# Altium schematic sheet Microcontroller.SchDoc(Microcontroller)
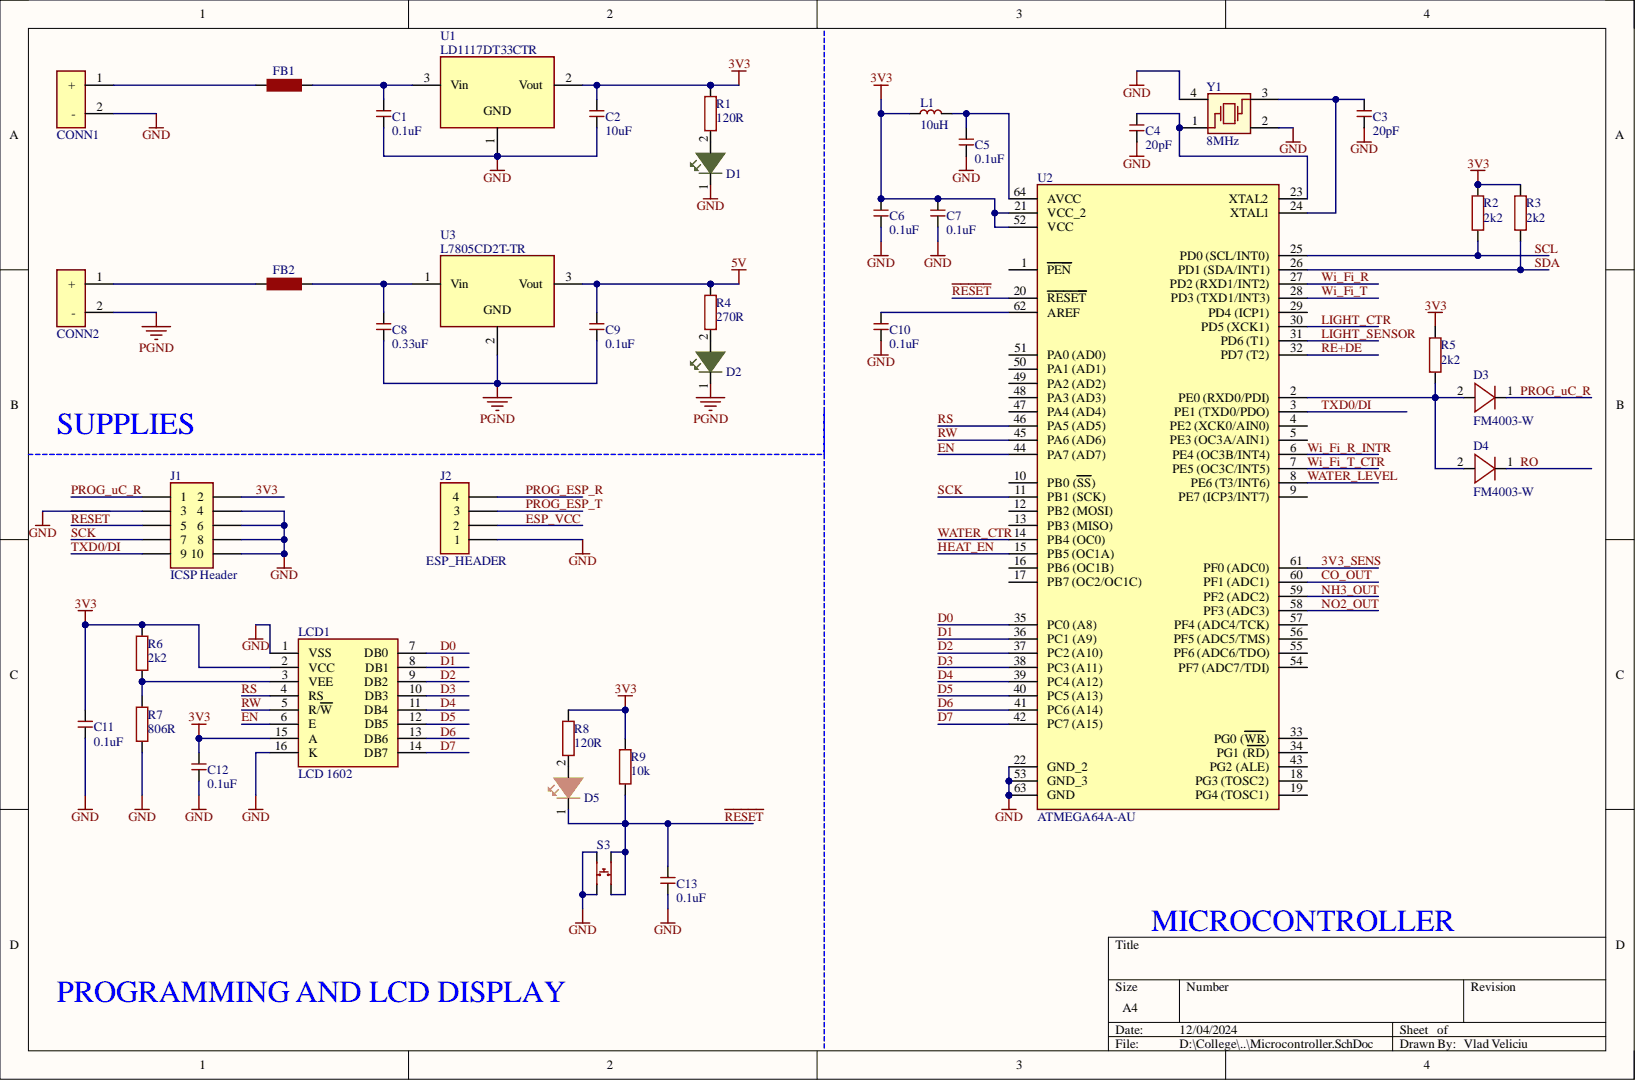
[diagram p0: Microcontroller.SchDoc]

## Parts
C1  0.1uF  [C0603]
C2  10uF  [C0805]
C3  20pF  [C0805]
C4  20pF  [C0805]
C5  0.1uF  [C0603]
C6  0.1uF  [C0603]
C7  0.1uF  [C0603]
C8  0.33uF  [C1206]
C9  0.1uF  [C0603]
C10  0.1uF  [C0603]
C11  0.1uF  [C0603]
C12  0.1uF  [C0603]
C13  0.1uF  [C0603]
CONN1  BAT_TERM  [1760510000]  (value not drawn)
CONN2  BAT_TERM  [1760510000]  (value not drawn)
D1  150060VS75000  [LED-0603]  (value not drawn)
D2  150060VS75000  [LED-0603]  (value not drawn)
D3  FM4003-W  [FM4003]
D4  FM4003-W  [FM4003]
D5  150060AS75000  [LED-0603]  (value not drawn)
FB1  BLM21SP181SZ1D  [FB-0805]  (value not drawn)
FB2  BLM21SP181SZ1D  [FB-0805]  (value not drawn)
J1  ICSP Header  [15916100]
J2  ESP_HEADER  [TMM-104-YY-XX-D-SM-4pins]
L1  10uH  [L-0805]
LCD1  LCD 1602  [LCD 1602]
R1  120R  [R0805]
R2  2k2  [R0805]
R3  2k2  [R0805]
R4  270R  [R1206]
R5  2k2  [R0805]
R6  2k2  [R0805]
R7  806R  [R0805]
R8  120R  [R0805]
R9  10k  [R0805]
S3  Pushbutton  [Pushbutton]  (value not drawn)
U1  LD1117DT33CTR  [DPAK]
U2  ATMEGA64A-AU  [64-TQFP]
U3  L7805CD2T-TR  [D2PAK]
Y1  8MHz  [ECS-80]

## Nets
5V: C9.2 R4.2 U3.3
GND: C1.1 C2.1 C3.1 C4.1 C5.1 C6.1 C7.1 C10.1 C11.1 C12.1 C13.1 CONN1.2 D1.1 J1.3 J1.4 J1.6 J1.8 J1.10 J2.1 LCD1.1(VSS) LCD1.16(K) R7.1 S3.3 S3.4 U1.1 U2.22(GND_2) U2.53(GND_3) U2.63(GND) Y1.2 Y1.4
PGND: C8.1 C9.1 CONN2.2 D2.1 U3.2
3V3: C2.2 C6.2 C7.2 C11.2 C12.2 J1.2 L1.1 LCD1.2(VCC) LCD1.15(A) R1.2 R2.2 R3.2 R5.2 R6.2 R8.2 R9.2 U1.2 U2.21(VCC_2) U2.52(VCC)
3V3_SENS: U2.61(PF0_(ADC0))
CO_OUT: U2.60(PF1_(ADC1))
D0: LCD1.7(DB0) U2.35(PC0_(A8))
D1: LCD1.8(DB1) U2.36(PC1_(A9))
D2: LCD1.9(DB2) U2.37(PC2_(A10))
D3: LCD1.10(DB3) U2.38(PC3_(A11))
D4: LCD1.11(DB4) U2.39(PC4_(A12))
D5: LCD1.12(DB5) U2.40(PC5_(A13))
D6: LCD1.13(DB6) U2.41(PC6_(A14))
D7: LCD1.14(DB7) U2.42(PC7_(A15))
EN: LCD1.6(E) U2.44(PA7_(AD7))
ESP_VCC: J2.2
HEAT_EN: U2.15(PB5_(OC1A))
LIGHT_CTR: U2.30(PD5_(XCK1))
LIGHT_SENSOR: U2.31(PD6_(T1))
NH3_OUT: U2.59(PF2_(ADC2))
NO2_OUT: U2.58(PF3_(ADC3))
PROG_ESP_R: J2.4
PROG_ESP_T: J2.3
PROG_uC_R: D3.1 J1.1
~{RESET}: C13.2 D5.1 J1.5 R9.1 S3.1 S3.2 U2.20(RESET)
RE+DE: U2.32(PD7_(T2))
RO: D4.1
RS: LCD1.4(RS) U2.46(PA5_(AD5))
RW: LCD1.5(R/W) U2.45(PA6_(AD6))
SCK: J1.7 U2.11(PB1_(SCK))
SCL: R2.1 U2.25(PD0_(SCL/INT0))
SDA: R3.1 U2.26(PD1_(SDA/INT1))
TXD0/DI: J1.9 U2.3(PE1_(TXD0/PDO))
WATER_CTR: U2.14(PB4_(OC0))
WATER_LEVEL: U2.8(PE6_(T3/INT6))
Wi_Fi_R: U2.27(PD2_(RXD1/INT2))
Wi_Fi_R_INTR: U2.6(PE4_(OC3B/INT4))
Wi_Fi_T: U2.28(PD3_(TXD1/INT3))
Wi_Fi_T_CTR: U2.7(PE5_(OC3C/INT5))
NetC1_2: C1.2 FB1.2 U1.3
NetC3_2: C3.2 U2.24(XTAL1) Y1.3
NetC4_2: C4.2 U2.23(XTAL2) Y1.1
NetC5_2: C5.2 L1.2 U2.64(AVCC)
NetC8_2: C8.2 FB2.2 U3.1
NetC10_2: C10.2 U2.62(AREF)
NetCONN1_1: CONN1.1 FB1.1
NetCONN2_1: CONN2.1 FB2.1
NetD1_2: D1.2 R1.1
NetD2_2: D2.2 R4.1
NetD3_2: D3.2 D4.2 R5.1 U2.2(PE0_(RXD0/PDI))
NetD5_2: D5.2 R8.1
NetLCD1_3: LCD1.3(VEE) R6.1 R7.2

Not connected: U2 1(PEN) 4(PE2_(XCK0/AIN0)) 5(PE3_(OC3A/AIN1)) 9(PE7_(ICP3/INT7)) 10(PB0_(SS)) 12(PB2_(MOSI)) 13(PB3_(MISO)) 16(PB6_(OC1B)) 17(PB7_(OC2/OC1C)) 18(PG3_(TOSC2)) 19(PG4_(TOSC1)) 29(PD4_(ICP1)) 33(PG0_(WR)) 34(PG1_(RD)) 43(PG2_(ALE)) 47(PA4_(AD4)) 48(PA3_(AD3)) 49(PA2_(AD2)) 50(PA1_(AD1)) 51(PA0_(AD0)) 54(PF7_(ADC7/TDI)) 55(PF6_(ADC6/TDO)) 56(PF5_(ADC5/TMS)) 57(PF4_(ADC4/TCK))

## Library data of the parts
C1 C5-C7 C9-C13 = C0603C104K8RAC: Ceramic Capacitor, Multilayer, Ceramic, 10V, 10% +Tol, 10% -Tol, X7R, 15% TC, 0.1uF, Surface Mount, 0603; Manufacturer: KEMET; Multilayer: Yes; Value: 100nF; Capacitor Type: Ceramic; Case/Package: 0603; Category: Ceramic Capacitors; Component Kind: Standard; ComponentLink1Description: https://datasheet.ciiva.com:443/pdfs/VipMasterIC/IC/KEME/KEME-S-A0008991654/KEME-S-A0008991654-1.pdf?src-supplier=IHS+Markit; ComponentLink1URL: https://datasheet.ciiva.com:443/pdfs/VipMasterIC/IC/KEME/KEME-S-A0008991654/KEME-S-A0008991654-1.pdf?src-supplier=IHS+Markit; ComponentLink2Description: http://www.mouser.com/ds/2/212/KEM_C1002_X7R_SMD-1102033.pdf?src-supplier=Mouser; ComponentLink2URL: http://www.mouser.com/ds/2/212/KEM_C1002_X7R_SMD-1102033.pdf?src-supplier=Mouser; Dielectric Material: Ceramic; Height: 0.8mm; JESD-609 Code: e3; Length: 1.6mm; Library Name: Schlib1.SchLib; Manufacturer Part Number: C0603C104K8RAC; Max Operating Temperature: 125°C; Min Operating Temperature: -55°C; Mounting Technology: Surface Mount; Package Shape: Rectangular; Package Style: SMTMeter; Packaging: Tape and Reel; Pins: 2; Pricing: 1=0.1, 10=0.061, 50=0.061, 100=0.031, 1000=0.022, 10000=0.015 (USD); Rated DC Voltage (URdc): 10V; Reach SVHC Compliant: Yes; RoHS: Yes; RoHS Compliant: Yes; Stock: 209299; Supplier: Mouser; Supplier Part Number: 80-C0603C104K8RAC; Temperature Characteristics Code: X7R; Terminal Finish: Nickel; Termination: Wraparound; Tolerance  (fill in as +-): 10%; Tolerance (fill in as +-): 10%; Width: 0.8mm
C2 = C0805C106K8PACTU: Ceramic Capacitor, Multilayer, Ceramic, 10V, 10% +Tol, 10% -Tol, X5R, 15% TC, 10uF, Surface Mount, 0805; Manufacturer: KEMET; Multilayer: Yes; Capacitor Type: Ceramic; Case/Package: 0805; Category: Ceramic Capacitors; Component Kind: Standard; ComponentLink1Description: https://datasheet.ciiva.com:443/pdfs/VipMasterIC/IC/KEME/KEME-S-A0008991665/KEME-S-A0008991665-1.pdf?src-supplier=IHS+Markit; ComponentLink1URL: https://datasheet.ciiva.com:443/pdfs/VipMasterIC/IC/KEME/KEME-S-A0008991665/KEME-S-A0008991665-1.pdf?src-supplier=IHS+Markit; ComponentLink2Description: https://datasheet.ciiva.com/7842/1732709-7842198.pdf?src-supplier=Element14; ComponentLink2URL: https://datasheet.ciiva.com/7842/1732709-7842198.pdf?src-supplier=Element14; ComponentLink3Description: https://datasheet.ciiva.com/22704/1732709-22704859.pdf?src-supplier=Element14; ComponentLink3URL: https://datasheet.ciiva.com/22704/1732709-22704859.pdf?src-supplier=Element14; ComponentLink4Description: https://datasheet.ciiva.com/7842/40889-7842552.pdf?src-supplier=Element14; ComponentLink4URL: https://datasheet.ciiva.com/7842/40889-7842552.pdf?src-supplier=Element14; Dielectric Material: Ceramic; Height: 1.25mm; JESD-609 Code: e3; Length: 2mm; Library Name: Schlib1.SchLib; Manufacturer Part Number: C0805C106K8PACTU; Max Operating Temperature: 85°C; Min Operating Temperature: -55°C; Mounting Technology: Surface Mount; Package Shape: Rectangular; Package Style: SMTMeter; Packaging: Tape and Reel; Pins: 2; Pricing: 1=0.14, 10=0.098, 50=0.0584, 100=0.0459, 500=0.03388, 1000=0.02805 (USD); Rated DC Voltage (URdc): 10V; Reach SVHC Compliant: Yes; RoHS: Yes; RoHS Compliant: Yes; Stock: 5642299; Supplier: Digikey; Supplier Part Number: 399-C0805C106K8PAC7800CT-ND; Temperature Characteristics Code: X5R; Terminal Finish: Nickel; Termination: Wraparound; Tolerance  (fill in as +-): 10%; Tolerance (fill in as +-): 10%; Value: 10uF; Width: 1.25mm
C3 C4 = C0805C200J3GACTU: Ceramic Capacitor, Multilayer, Ceramic, 25V, 5% +Tol, 5% -Tol, C0G, 30ppm/Cel TC, 0.00002uF, Surface Mount, 0805; Manufacturer: KEMET; Multilayer: Yes; Capacitor Type: Ceramic; Case/Package: 0805; Category: Ceramic Capacitors; Component Kind: Standard; ComponentLink1Description: https://datasheet.ciiva.com:443/pdfs/VipMasterIC/IC/KEME/KEME-S-A0008839401/KEME-S-A0008839438-1.pdf?src-supplier=IHS+Markit; ComponentLink1URL: https://datasheet.ciiva.com:443/pdfs/VipMasterIC/IC/KEME/KEME-S-A0008839401/KEME-S-A0008839438-1.pdf?src-supplier=IHS+Markit; ComponentLink2Description: http://components.arrow.com/part/dataview/30753995S8614462N7429?src-supplier=Arrow+Electronics; ComponentLink2URL: http://components.arrow.com/part/dataview/30753995S8614462N7429?src-supplier=Arrow+Electronics; ComponentLink3Description: http://www.mouser.com/ds/2/212/KEM_C1003_C0G_SMD-1101588.pdf?src-supplier=Mouser; ComponentLink3URL: http://www.mouser.com/ds/2/212/KEM_C1003_C0G_SMD-1101588.pdf?src-supplier=Mouser; ComponentLink4Description: https://api.kemet.com/component-edge/download/datasheet/C0805C200J3GACTU.pdf?src-supplier=Digikey; ComponentLink4URL: https://api.kemet.com/component-edge/download/datasheet/C0805C200J3GACTU.pdf?src-supplier=Digikey; Dielectric Material: Ceramic; Height: 0.78mm; JESD-609 Code: e3; Length: 2mm; Library Name: Schlib1.SchLib; Manufacturer Part Number: C0805C200J3GACTU; Max Operating Temperature: 125°C; Min Operating Temperature: -55°C; Mounting Technology: Surface Mount; Package Shape: Rectangular; Package Style: SMTMeter; Packaging: Tape and Reel; Pins: 2; Pricing: 1=0.2, 10=0.122, 50=0.122, 100=0.073, 1000=0.064, 10000=0.05 (USD); Rated DC Voltage (URdc): 25V; Reach SVHC Compliant: Yes; RoHS: Yes; RoHS Compliant: Yes; Stock: 10386; Supplier: Mouser; Supplier Part Number: 80-C0805C200J3G; Temperature Characteristics Code: C0G; Terminal Finish: Nickel; Termination: Wraparound; Tolerance  (fill in as +-): 5%; Tolerance (fill in as +-): 5%; Value: 20pF; Width: 1.25mm
C8 = C1206C334K1RECAUTO: Ceramic Capacitor, Multilayer, Ceramic, 100V, 10% +Tol, 10% -Tol, X7R, 15% TC, 0.33uF, Surface Mount, 1206; Manufacturer: KEMET; Capacitor Type: Ceramic; Category: Ceramic Capacitors; Component Kind: Standard; ComponentLink1Description: https://datasheet.ciiva.com:443/pdfs/VipMasterIC/IC/KEME/KEME-S-A0008958450/KEME-S-A0008958450-1.pdf?src-supplier=IHS+Markit; ComponentLink1URL: https://datasheet.ciiva.com:443/pdfs/VipMasterIC/IC/KEME/KEME-S-A0008958450/KEME-S-A0008958450-1.pdf?src-supplier=IHS+Markit; ComponentLink2Description: https://api.kemet.com/component-edge/download/datasheet/C1206C334K1RECAUTO.pdf?src-supplier=Digikey; ComponentLink2URL: https://api.kemet.com/component-edge/download/datasheet/C1206C334K1RECAUTO.pdf?src-supplier=Digikey; Dielectric Material: Ceramic; Library Name: Schlib1.SchLib; Manufacturer Part Number: C1206C334K1RECAUTO; Pins: 2; Pricing: 1=0.31, 10=0.15, 50=0.15, 100=0.096, 1000=0.081, 10000=0.066 (USD); Reach SVHC Compliant: Yes; RoHS: Yes; RoHS Compliant: Yes; Stock: 50773; Supplier: Mouser; Supplier Part Number: 80-C1206C334K1RECAUT
CONN1 CONN2 = BAT_TERM: Strip Terminal Block, 24A, 2.5mm2, 1 Row(s), 1 Deck(s); Manufacturer: Weidmuller; Additional Feature: STANDARD: UL 94V-0; Fastening Method: SOLDER; Number of Decks: 1; Number of Ways: 2; Safety Approval: UL,CSA; Voltage Rating: 600V; Wire/Cable Gauge: 14AWG; Category: Terminal Blocks; Component Kind: Standard; ComponentLink1Description: https://datasheet.ciiva.com:443/pdfs/VipMasterIC/IC/WEID/WEIDS07857/WEIDS07857-1.pdf?src-supplier=IHS+Markit; ComponentLink1URL: https://datasheet.ciiva.com:443/pdfs/VipMasterIC/IC/WEID/WEIDS07857/WEIDS07857-1.pdf?src-supplier=IHS+Markit; ComponentLink2Description: https://datasheet.ciiva.com/823/1760510000-823577.pdf?src-supplier=Digikey; ComponentLink2URL: https://datasheet.ciiva.com/823/1760510000-823577.pdf?src-supplier=Digikey; ComponentLink3Description: https://datasheet.ciiva.com/7143/1712606-7143944.pdf?src-supplier=Newark; ComponentLink3URL: https://datasheet.ciiva.com/7143/1712606-7143944.pdf?src-supplier=Newark; ComponentLink4Description: https://datasheet.ciiva.com/16071/1760510000-pm-5-08-02-90-3-5sn-bk-bx-en-pdf-154-16071541.pdf?src-supplier=Onlinecomponents.com; ComponentLink4URL: https://datasheet.ciiva.com/16071/1760510000-pm-5-08-02-90-3-5sn-bk-bx-en-pdf-154-16071541.pdf?src-supplier=Onlinecomponents.com; Current Rating: 24A; Library Name: Schlib1.SchLib; Manufacturer Part Number: 1760510000; Mounting Technology: Board; Number of Rows: 1; Pricing: 1=0.89, 10=0.554, 25=0.474, 50=0.4262, 100=0.4173, 250=0.35512, 500=0.33736, 1000=0.30185, 5000=0.23615 (USD); Reach SVHC Compliant: Yes; RoHS: Yes; RoHS Compliant: Yes; Stock: 49588; Supplier: Digikey; Supplier Part Number: 281-1882-ND; Terminal and Terminal Block Type: STRIP TERMINAL BLOCK; Wire Cross Section: 2.5mm2
D1 D2 = 150060VS75000: Single Color LED, Bright Green, Water Clear, 1.1mm; Manufacturer: Wurth Electronics; Channels: 1; Forward Current: 30mA; Luminous Intensity-Nom: 40mcd; Overall Height: 0.7mm; Shape: Rectangular; Viewing Angle: 140°; Category: LEDs; Color: Green; Component Kind: Standard; ComponentLink1Description: https://datasheet.ciiva.com:443/pdfs/VipMasterIC/IC/MIDC/MIDC-S-A0007768636/MIDC-S-A0007768636-1.pdf?src-supplier=IHS+Markit; ComponentLink1URL: https://datasheet.ciiva.com:443/pdfs/VipMasterIC/IC/MIDC/MIDC-S-A0007768636/MIDC-S-A0007768636-1.pdf?src-supplier=IHS+Markit; ComponentLink2Description: https://datasheet.ciiva.com/29562/150060vs75000-29562919.pdf?src-supplier=Digikey; ComponentLink2URL: https://datasheet.ciiva.com/29562/150060vs75000-29562919.pdf?src-supplier=Digikey; Lens Style: WATER CLEAR; Library Name: Schlib1.SchLib; Manufacturer Part Number: 150060VS75000; Max Operating Temperature: 85°C; Min Operating Temperature: -40°C; Mounting Technology: Surface Mount; Optoelectronic Device Type: SINGLE COLOR LED; Packaging: Tape and Reel; Pins: 2; Pricing: 1=0.15, 50=0.142, 100=0.122, 500=0.105, 1000=0.10125 (USD); Reach SVHC Compliant: Yes; RoHS: Yes; RoHS Compliant: Yes; Size: 1.1mm; Stock: 90757; Supplier: Digikey; Supplier Part Number: 732-4980-1-ND; Terminal Pitch: 1.6mm
D3 D4 = FM4003-W: Rectifier Diode, 1 Phase, 1 Element, 1A, 200V V(RRM), Silicon, DO-214AC; Manufacturer: Rectron; Forward Voltage: 1.1V; Non-rep Pk Forward Current-Max: 30A; Number of Elements: 1; Package Body Material: Plastic; Qualification Status: Not Qualified; Time @ Peak Reflow Temperature-Max (s): 10s; Category: Rectifier Diodes; Component Kind: Standard; ComponentLink1Description: https://datasheet.ciiva.com:443/pdfs/VipMasterIC/IC/RECT/RECT-S-A0006483850/RECT-S-A0006483850-1.pdf?src-supplier=IHS+Markit; ComponentLink1URL: https://datasheet.ciiva.com:443/pdfs/VipMasterIC/IC/RECT/RECT-S-A0006483850/RECT-S-A0006483850-1.pdf?src-supplier=IHS+Markit; ComponentLink2Description: http://www.mouser.com/ds/2/345/rectron_fm4001w-fm4007w-347498.pdf?src-supplier=Mouser; ComponentLink2URL: http://www.mouser.com/ds/2/345/rectron_fm4001w-fm4007w-347498.pdf?src-supplier=Mouser; Diode Element Material: SILICON; Diode Type: RECTIFIER; JEDEC-95 Code: DO-214AC; JESD-30 Code: R-PDSO-C2; JESD-609 Code: e3; Library Name: Schlib1.SchLib; Manufacturer Part Number: FM4003-W; Max Operating Temperature: 150°C; Max Output current: 1A; Package Shape: Rectangular; Package Style: SMALL OUTLINEMeter; Peak Reflow Temperature (Cel): 260°C; Pins: 2; Pricing: 1=0.24, 10=0.16, 50=0.16, 100=0.089, 1000=0.052, 10000=0.034 (USD); Reach SVHC Compliant: No; Rep Pk Reverse Voltage-Max: 200V; RoHS: Yes; RoHS Compliant: Yes; Stock: 13549; Supplier: Mouser; Supplier Part Number: 583-FM4003-W; Surface Mount: Yes; Terminal Finish: Tin; Terminal Form: C BEND; Terminal Position: DUAL
D5 = 150060AS75000: Single Color LED, Amber, Water Clear, 1.1mm; Manufacturer: Wurth Electronics; Category: LEDs; Component Kind: Standard; ComponentLink1Description: https://datasheet.ciiva.com:443/pdfs/VipMasterIC/IC/MIDC/MIDC-S-A0007768872/MIDC-S-A0007768872-1.pdf?src-supplier=IHS+Markit; ComponentLink1URL: https://datasheet.ciiva.com:443/pdfs/VipMasterIC/IC/MIDC/MIDC-S-A0007768872/MIDC-S-A0007768872-1.pdf?src-supplier=IHS+Markit; ComponentLink2Description: http://www.farnell.com/datasheets/2621639.pdf?src-supplier=Farnell; ComponentLink2URL: http://www.farnell.com/datasheets/2621639.pdf?src-supplier=Farnell; ComponentLink3Description: http://www.farnell.com/datasheets/2621639.pdf?src-supplier=Element14; ComponentLink3URL: http://www.farnell.com/datasheets/2621639.pdf?src-supplier=Element14; ComponentLink4Description: http://www.farnell.com/datasheets/2621639.pdf?src-supplier=Newark; ComponentLink4URL: http://www.farnell.com/datasheets/2621639.pdf?src-supplier=Newark; Library Name: Schlib1.SchLib; Manufacturer Part Number: 150060AS75000; Optoelectronic Device Type: SINGLE COLOR LED; Pricing: 1=0.15, 50=0.142, 100=0.122, 500=0.105 (USD); Reach SVHC Compliant: Yes; RoHS: Yes; RoHS Compliant: Yes; Stock: 19678; Supplier: Digikey; Supplier Part Number: 732-150060AS75000CT-ND
FB1 FB2 = BLM21SP181SZ1D: Ferrite Chip, 1 Function(s), 2.6A, 2 Pin(s); Manufacturer: Murata; Category: Ferrite Beads and Chips; Component Kind: Standard; ComponentLink1Description: https://datasheet.ciiva.com:443/pdfs/VipMasterIC/IC/MURE/MURE-S-A0008531011/MURE-S-A0008530072-1.pdf?src-supplier=IHS+Markit; ComponentLink1URL: https://datasheet.ciiva.com:443/pdfs/VipMasterIC/IC/MURE/MURE-S-A0008531011/MURE-S-A0008530072-1.pdf?src-supplier=IHS+Markit; ComponentLink2Description: http://www.farnell.com/datasheets/2622769.pdf?src-supplier=Farnell; ComponentLink2URL: http://www.farnell.com/datasheets/2622769.pdf?src-supplier=Farnell; ComponentLink3Description: https://www.murata.com/en-us/api/pdfdownloadapi?cate=luNoiseSupprFilteChipFerriBead&amp;partno=BLM21SP181SZ1%23&src-supplier=Digikey; ComponentLink3URL: https://www.murata.com/en-us/api/pdfdownloadapi?cate=luNoiseSupprFilteChipFerriBead&amp;partno=BLM21SP181SZ1%23&src-supplier=Digikey; ComponentLink4Description: http://www.farnell.com/datasheets/2622769.pdf?src-supplier=Element14; ComponentLink4URL: http://www.farnell.com/datasheets/2622769.pdf?src-supplier=Element14; ComponentLink5Description: http://www.farnell.com/datasheets/2622769.pdf?src-supplier=Newark; ComponentLink5URL: http://www.farnell.com/datasheets/2622769.pdf?src-supplier=Newark; Filter Type: FERRITE CHIP; Library Name: Schlib1.SchLib; Manufacturer Part Number: BLM21SP181SZ1D; Pricing: 1=0.26, 10=0.213, 25=0.1968, 50=0.1804, 100=0.1591, 250=0.1542, 500=0.13778, 1000=0.11154 (USD); Reach SVHC Compliant: Yes; RoHS: Yes; RoHS Compliant: Yes; Stock: 5057; Supplier: Digikey; Supplier Part Number: 490-18599-1-ND
J1 = 15-91-6100: Headers & Wire Housings CGrid SMT Bkwy Hdr T wy Hdr Tin 10Ckt T&R; Manufacturer: Molex; Category: Headers and Wire Housings; Component Kind: Standard; ComponentLink1Description: https://datasheet.ciiva.com/13571/0015916100-pcb-headers-158017-13571672.pdf?src-supplier=Mouser; ComponentLink1URL: https://datasheet.ciiva.com/13571/0015916100-pcb-headers-158017-13571672.pdf?src-supplier=Mouser; ComponentLink2Description: https://datasheet.ciiva.com/16727/0015916100-pcb-headers-16727185.pdf?; ComponentLink2URL: https://datasheet.ciiva.com/16727/0015916100-pcb-headers-16727185.pdf?; Library Name: Schlib1.SchLib; Manufacturer Part Number: 15-91-6100; Pricing: 1=3.33, 10=2.934, 100=2.3336 (USD); RoHS: Yes; Stock: 464; Supplier: Digikey; Supplier Part Number: 900-0015916100CT-ND
J2 = TMM-104-06-F-D-SM_4pins: Board Connector, 8 Contact(s), 2 Row(s), Male, Straight, 0.079 inch Pitch, Surface Mount Terminal, Black Insulator; Manufacturer: Samtec; Manufacturer Series: TMM-1; Body Breadth: 0.155inch; Body Depth: 0.109inch; Body Length: 0.316inch; Contact Pattern: RECTANGULAR; Contact Style: SQ PIN-SKT; DIN Conformance: No; Mounting Option 1: LOCKING; Number Of Connectors: 1; Number of Contacts: 8; Number Of PCB Rows: 2; Option: General Purpose; Plating Thickness: 3uin; Reference Standard: UL; Reliability: COMMERCIAL; Category: Headers and Wire Housings; Component Kind: Standard; ComponentLink1Description: https://datasheet.ciiva.com:443/pdfs/VipMasterIC/IC/SAMI/SAMI-S-A0001541321/SAMI-S-A0001541321-1.pdf?src-supplier=IHS+Markit; ComponentLink1URL: https://datasheet.ciiva.com:443/pdfs/VipMasterIC/IC/SAMI/SAMI-S-A0001541321/SAMI-S-A0001541321-1.pdf?src-supplier=IHS+Markit; ComponentLink2Description: https://datasheet.ciiva.com/10163/tmm-sm-10163085.pdf?src-supplier=Avnet; ComponentLink2URL: https://datasheet.ciiva.com/10163/tmm-sm-10163085.pdf?src-supplier=Avnet; Connector Type: BOARD CONNECTOR; Contact Finish - Mating: GOLD FLASH (3) OVER NICKEL (50); Contact Finish - Termination: MATTE TIN; Contact Gender: Male; Contact Material: Bronze; Filter Feature: No; Flammability Rating: UL94 V-0; IEC Conformance: No; Insulation Material: Polymer; JESD-609 Code: e3; Library Name: Schlib1.SchLib; Manufacturer Part Number: TMM-104-06-F-D-SM; Mating Contact Pitch: 0.079inch; Mating Contact Row Spacing: 0.079inch; MIL Conformance: No; Mixed Contacts: No; Mounting Style: STRAIGHT; Mounting Technology: Board; Number of Rows Loaded: 2; PCB Contact Pattern: RECTANGULAR; Pricing: 1=1.64, 10=1.424, 100=1.2726, 500=1.0605, 1000=0.909, 5000=0.80598, 10000=0.7878 (USD); Reach SVHC Compliant: Yes; RoHS: Yes; RoHS Compliant: Yes; Stock: 201; Supplier: Digikey; Supplier Part Number: TMM-104-06-F-D-SM-ND; Terminal Pitch: 2mm; Termination: SMD/SMT
L1 = AIML-0805-100K-T: General Purpose Inductor, 10uH, 10%, 1 Element, Ferrite-Core, SMD, 0805; Manufacturer: Abracon; Case/Size Code: 0805; Channels: 1; DC Resistance (DCR): 1.15R; Inductor Application: FILTER CHOKE; Max Current Rating: 15mA; Package Height: 0.85mm; Package Length: 2mm; Package Width: 1.25mm; Quality Factor-Min (at L-nom): 55; Self Resonant Frequency: 24MHz; Shape/Size Description: RECTANGULAR PACKAGE; Shielding: Shielded; Test Frequency: 2MHz; Category: Fixed Inductors; Component Kind: Standard; ComponentLink1Description: https://datasheet.ciiva.com:443/pdfs/VipMasterIC/IC/ABRC/ABRC-S-A0008586284/ABRC-S-A0008586284-1.pdf?src-supplier=IHS+Markit; ComponentLink1URL: https://datasheet.ciiva.com:443/pdfs/VipMasterIC/IC/ABRC/ABRC-S-A0008586284/ABRC-S-A0008586284-1.pdf?src-supplier=IHS+Markit; ComponentLink2Description: https://datasheet.ciiva.com/1053/aiml0805-1206-1053697.pdf?src-supplier=Digikey; ComponentLink2URL: https://datasheet.ciiva.com/1053/aiml0805-1206-1053697.pdf?src-supplier=Digikey; ComponentLink3Description: https://datasheet.ciiva.com/1053/aiml0805-1206-1053698.pdf?src-supplier=Digikey; ComponentLink3URL: https://datasheet.ciiva.com/1053/aiml0805-1206-1053698.pdf?src-supplier=Digikey; ComponentLink4Description: https://datasheet.ciiva.com/1053/aiml0805-1206-1053699.pdf?src-supplier=Digikey; ComponentLink4URL: https://datasheet.ciiva.com/1053/aiml0805-1206-1053699.pdf?src-supplier=Digikey; Construction: Multilayer Chip; Core Material: Ferrite; Inductance-Nom (L): 10uH; Inductor Type: GENERAL PURPOSE INDUCTOR; JESD-609 Code: e3; Library Name: Schlib1.SchLib; Manufacturer Part Number: AIML-0805-100K-T; Manufacturer Series: AIML-0805; Max Operating Temperature: 125°C; Min Operating Temperature: -55°C; Package Style: SMTMeter; Packaging: Tape and Reel; Pins: 2; Pricing: 1=0.14, 10=0.112, 25=0.1032, 50=0.0908, 100=0.0795, 250=0.07576, 500=0.0712, 1000=0.05454 (USD); Reach SVHC Compliant: Yes; RoHS: Yes; RoHS Compliant: Yes; Series: AIML-0805; Stock: 4904; Supplier: Digikey; Supplier Part Number: 535-11621-1-ND; Surface Mount: Yes; Terminal Finish: Nickel; Terminal Placement: Dual Ended; Termination: Wraparound; Tolerance: 10%
LCD1 = LCD 1602: Display; Component Kind: Standard; Datasheet Link: https://www.waveshare.com/datasheet/LCD_en_PDF/LCD1602.pdf; Library Name: Schlib1.SchLib
R1 R8 = RT0805DRD07120RL: Fixed Resistor, Thin Film, 0.125W, 120ohm, 150V, 0.5% +/-Tol, 25ppm/Cel, Surface Mount, 0805; Manufacturer: Yageo; Additional Feature: HIGH PRECISION; Package Height: 0.5mm; Package Length: 2mm; Package Width: 1.25mm; Power: 125mW; Rated Temperature: 70°C; Temperature Coefficient: 25ppm/°C; Case/Package: 0805; Category: Chip SMD Resistors; Component Kind: Standard; ComponentLink1Description: https://datasheet.ciiva.com:443/pdfs/VipMasterIC/IC/YAGO/YAGO-S-A0008510989/YAGO-S-A0008510989-1.pdf?src-supplier=IHS+Markit; ComponentLink1URL: https://datasheet.ciiva.com:443/pdfs/VipMasterIC/IC/YAGO/YAGO-S-A0008510989/YAGO-S-A0008510989-1.pdf?src-supplier=IHS+Markit; ComponentLink2Description: http://www.yageo.com/documents/recent/PYu-RT_1-to-0.01_RoHS_L_9.pdf?src-supplier=Digikey; ComponentLink2URL: http://www.yageo.com/documents/recent/PYu-RT_1-to-0.01_RoHS_L_9.pdf?src-supplier=Digikey; Construction: Chip; Library Name: Schlib1.SchLib; Manufacturer Part Number: RT0805DRD07120RL; Max Operating Temperature: 155°C; Min Operating Temperature: -55°C; Mounting Technology: Surface Mount; Package Style: SMTMeter; Packaging: Tape and Reel; Pins: 2; Pricing: 1=0.1, 10=0.076, 50=0.0606, 100=0.0545, 500=0.0418, 1000=0.03701 (USD); Reach SVHC Compliant: Yes; Resistor Type: FIXED RESISTOR; RoHS: Yes; RoHS Compliant: Yes; Stock: 4920; Supplier: Digikey; Supplier Part Number: 13-RT0805DRD07120RLCT-ND; Technology: THIN FILM; Termination: Wraparound; Tolerance: 0.5%; Value: 120R; Working Voltage: 150V
R2 R3 R5 R6 = RC0805DR-072K2L: Fixed Resistor, Metal Glaze/thick Film, 0.125W, 2200ohm, 150V, 0.5% +/-Tol, 100ppm/Cel, Surface Mount, 0805; Manufacturer: Yageo; Additional Feature: LASER TRIMMABLE; Package Height: 0.5mm; Package Length: 2mm; Package Width: 1.25mm; Power: 125mW; Rated Temperature: 70°C; Temperature Coefficient: 100ppm/°C; Value: 2.2kR; Case/Package: 0805; Category: Chip SMD Resistors; Component Kind: Standard; ComponentLink1Description: https://datasheet.ciiva.com:443/pdfs/VipMasterIC/IC/YAGO/YAGO-S-A0008145938/YAGO-S-A0008145938-1.pdf?src-supplier=IHS+Markit; ComponentLink1URL: https://datasheet.ciiva.com:443/pdfs/VipMasterIC/IC/YAGO/YAGO-S-A0008145938/YAGO-S-A0008145938-1.pdf?src-supplier=IHS+Markit; ComponentLink2Description: http://www.yageo.com.tw/exep/pages/download/literatures/PYu-R_INT-thick_7.pdf?src-supplier=Digikey; ComponentLink2URL: http://www.yageo.com.tw/exep/pages/download/literatures/PYu-R_INT-thick_7.pdf?src-supplier=Digikey; Construction: Chip; JESD-609 Code: e3; Library Name: Schlib1.SchLib; Manufacturer Part Number: RC0805DR-072K2L; Max Operating Temperature: 155°C; Min Operating Temperature: -55°C; Mounting Technology: Surface Mount; Package Shape: Rectangular; Package Style: SMTMeter; Packaging: Tape and Reel; Pins: 2; Pricing: 1=0.13, 10=0.063, 50=0.0414, 100=0.0352, 500=0.02476, 1000=0.02156 (USD); Reach SVHC Compliant: Yes; Resistor Type: FIXED RESISTOR; RoHS: Yes; RoHS Compliant: Yes; Stock: 19275; Supplier: Digikey; Supplier Part Number: 13-RC0805DR-072K2LCT-ND; Technology: METAL GLAZE/THICK FILM; Terminal Finish: Nickel; Termination: Wraparound; Tolerance: 0.5%; Working Voltage: 150V
R4 = RC1206FR-07270RL: Fixed Resistor, Metal Glaze/thick Film, 0.25W, 270ohm, 200V, 1% +/-Tol, 100ppm/Cel, Surface Mount, 1206; Manufacturer: Yageo; Additional Feature: LASER TRIMMABLE; Package Height: 0.55mm; Package Length: 3.1mm; Package Width: 1.6mm; Power: 250mW; Rated Temperature: 70°C; Temperature Coefficient: 100ppm/°C; Case/Package: 1206; Category: Chip SMD Resistors; Component Kind: Standard; ComponentLink1Description: https://datasheet.ciiva.com:443/pdfs/VipMasterIC/IC/YAGO/YAGO-S-A0008145938/YAGO-S-A0008145938-1.pdf?src-supplier=IHS+Markit; ComponentLink1URL: https://datasheet.ciiva.com:443/pdfs/VipMasterIC/IC/YAGO/YAGO-S-A0008145938/YAGO-S-A0008145938-1.pdf?src-supplier=IHS+Markit; ComponentLink2Description: https://datasheet.ciiva.com/11819/0900766b80c6630a-11819935.pdf?src-supplier=Rs; ComponentLink2URL: https://datasheet.ciiva.com/11819/0900766b80c6630a-11819935.pdf?src-supplier=Rs; ComponentLink3Description: https://datasheet.ciiva.com/6547/pyu-rc1206-51-rohs-l-4-6547272.pdf?src-supplier=Digikey; ComponentLink3URL: https://datasheet.ciiva.com/6547/pyu-rc1206-51-rohs-l-4-6547272.pdf?src-supplier=Digikey; ComponentLink4Description: https://datasheet.ciiva.com/6547/pyu-rc1206-51-rohs-l-4-6547273.pdf?src-supplier=Digikey; ComponentLink4URL: https://datasheet.ciiva.com/6547/pyu-rc1206-51-rohs-l-4-6547273.pdf?src-supplier=Digikey; ComponentLink5Description: https://datasheet.ciiva.com/6547/pyu-rc1206-51-rohs-l-4-6547274.pdf?src-supplier=Digikey; ComponentLink5URL: https://datasheet.ciiva.com/6547/pyu-rc1206-51-rohs-l-4-6547274.pdf?src-supplier=Digikey; ComponentLink6Description: https://datasheet.ciiva.com/7857/444357-7857309.pdf?src-supplier=Element14; ComponentLink6URL: https://datasheet.ciiva.com/7857/444357-7857309.pdf?src-supplier=Element14; ComponentLink7Description: https://datasheet.ciiva.com/7857/444357-7857310.pdf?src-supplier=Element14; ComponentLink7URL: https://datasheet.ciiva.com/7857/444357-7857310.pdf?src-supplier=Element14; ComponentLink8Description: https://datasheet.ciiva.com/17052/pyu-rc1206-51-rohs-l-4-17052059.pdf?src-supplier=Future+Electronics; ComponentLink8URL: https://datasheet.ciiva.com/17052/pyu-rc1206-51-rohs-l-4-17052059.pdf?src-supplier=Future+Electronics; Construction: Chip; JESD-609 Code: e3; Library Name: Schlib1.SchLib; Manufacturer Part Number: RC1206FR-07270RL; Max Operating Temperature: 155°C; Min Operating Temperature: -55°C; Mounting Technology: Surface Mount; Package Shape: Rectangular; Package Style: SMTMeter; Packaging: Tape and Reel; Pins: 2; Pricing: 1=0.1, 10=0.038, 50=0.0244, 100=0.0206, 500=0.01434, 1000=0.01248 (USD); Reach SVHC Compliant: Yes; Resistor Type: FIXED RESISTOR; RoHS: Yes; RoHS Compliant: Yes; Stock: 85450; Supplier: Digikey; Supplier Part Number: 311-270FRCT-ND; Technology: METAL GLAZE/THICK FILM; Terminal Finish: Nickel; Termination: Wraparound; Tolerance: 1%; Value: 270R; Working Voltage: 200V
R7 = RT0805BRD07806RL: Fixed Resistor, Thin Film, 0.125W, 806ohm, 150V, 0.1% +/-Tol, 25ppm/Cel, Surface Mount, 0805; Manufacturer: Yageo; Additional Feature: HIGH PRECISION; Package Height: 0.5mm; Package Length: 2mm; Package Width: 1.25mm; Power: 125mW; Rated Temperature: 70°C; Temperature Coefficient: 25ppm/°C; Case/Package: 0805; Category: Chip SMD Resistors; Component Kind: Standard; ComponentLink1Description: https://datasheet.ciiva.com:443/pdfs/VipMasterIC/IC/YAGO/YAGO-S-A0008510989/YAGO-S-A0008510989-1.pdf?src-supplier=IHS+Markit; ComponentLink1URL: https://datasheet.ciiva.com:443/pdfs/VipMasterIC/IC/YAGO/YAGO-S-A0008510989/YAGO-S-A0008510989-1.pdf?src-supplier=IHS+Markit; ComponentLink2Description: https://datasheet.ciiva.com/2585/pyu-rt-1-to-0-05-rohs-l-5-2585181.pdf?src-supplier=Digikey; ComponentLink2URL: https://datasheet.ciiva.com/2585/pyu-rt-1-to-0-05-rohs-l-5-2585181.pdf?src-supplier=Digikey; Construction: Chip; Library Name: Schlib1.SchLib; Manufacturer Part Number: RT0805BRD07806RL; Max Operating Temperature: 155°C; Min Operating Temperature: -55°C; Mounting Technology: Surface Mount; Package Style: SMTMeter; Packaging: Tape and Reel; Pins: 2; Pricing: 1=0.16, 10=0.12, 50=0.095, 100=0.085, 1000=0.057, 10000=0.038 (USD); Reach SVHC Compliant: Yes; Resistor Type: FIXED RESISTOR; RoHS: Yes; RoHS Compliant: Yes; Stock: 2476; Supplier: Mouser; Supplier Part Number: 603-RT0805BRD07806RL; Technology: THIN FILM; Termination: Wraparound; Tolerance: 0.1%; Value: 806R; Working Voltage: 150V
R9 = RT0805DRD0710KL: Fixed Resistor, Thin Film, 0.125W, 10000ohm, 150V, 0.5% +/-Tol, 25ppm/Cel, Surface Mount, 0805; Manufacturer: Yageo; Additional Feature: HIGH PRECISION; Package Height: 0.5mm; Package Length: 2mm; Package Width: 1.25mm; Power: 125mW; Rated Temperature: 70°C; Temperature Coefficient: 25ppm/°C; Value: 10kR; Case/Package: 0805; Category: Chip SMD Resistors; Component Kind: Standard; ComponentLink1Description: https://datasheet.ciiva.com:443/pdfs/VipMasterIC/IC/YAGO/YAGO-S-A0008510989/YAGO-S-A0008510989-1.pdf?src-supplier=IHS+Markit; ComponentLink1URL: https://datasheet.ciiva.com:443/pdfs/VipMasterIC/IC/YAGO/YAGO-S-A0008510989/YAGO-S-A0008510989-1.pdf?src-supplier=IHS+Markit; ComponentLink2Description: https://datasheet.ciiva.com/8891/pyu-rt-1-to-0-05-rohs-l-4-8891431.pdf?src-supplier=Avnet; ComponentLink2URL: https://datasheet.ciiva.com/8891/pyu-rt-1-to-0-05-rohs-l-4-8891431.pdf?src-supplier=Avnet; Construction: Chip; Library Name: Schlib1.SchLib; Manufacturer Part Number: RT0805DRD0710KL; Max Operating Temperature: 155°C; Min Operating Temperature: -55°C; Mounting Technology: Surface Mount; Package Style: SMTMeter; Packaging: Tape and Reel; Pins: 2; Pricing: 1=0.1, 10=0.059, 50=0.059, 100=0.048, 1000=0.033, 10000=0.021 (USD); Reach SVHC Compliant: Yes; Resistor Type: FIXED RESISTOR; RoHS: Yes; RoHS Compliant: Yes; Stock: 16743; Supplier: Mouser; Supplier Part Number: 603-RT0805DRD0710KL; Technology: THIN FILM; Termination: Wraparound; Tolerance: 0.5%; Working Voltage: 150V
S3 = Pushbutton: BUTON MINI 6X6X5, 4 PINI; Component Kind: Standard; Library Name: Schlib1.SchLib; Price: 0.36 RON; Supplier: Sigmanortec
U1 = LD1117DT33CTR: Fixed Positive LDO Regulator, 3.3V, 1.3V Dropout, BIPolar, PSSO2; Manufacturer: STMicroelectronics; Channels: 1; Dropout Voltage1-Nom: 1.1V; Input Voltage Absolute-Max: 15V; Line Regulation-Max: 0.03%; Min Input Voltage: 4.75V; Output Current1-Max: 1.3A; Output Voltage1-Max: 3.44V; Output Voltage1-Min: 3.16V; Package Body Material: Plastic; Package Equivalence Code: SMSIP3H,.38,90TB; Qualification Status: Not Qualified; Regulator Type: FIXED POSITIVE SINGLE OUTPUT LDO REGULATOR; Time @ Peak Reflow Temperature-Max (s): 30s; Voltage Tolerance-Max: 4.2%; Adjustability: FIXED; Case/Package: TO-252; Category: Voltage Regulators - Linear; Component Kind: Standard; ComponentLink1Description: https://datasheet.ciiva.com:443/pdfs/VipMasterIC/IC/SGST/SGST-S-A0009691890/SGST-S-A0009691890-1.pdf?src-supplier=IHS+Markit; ComponentLink1URL: https://datasheet.ciiva.com:443/pdfs/VipMasterIC/IC/SGST/SGST-S-A0009691890/SGST-S-A0009691890-1.pdf?src-supplier=IHS+Markit; ComponentLink2Description: https://datasheet.ciiva.com/27055/1991838-27055800.pdf?src-supplier=Jameco; ComponentLink2URL: https://datasheet.ciiva.com/27055/1991838-27055800.pdf?src-supplier=Jameco; ComponentLink3Description: https://datasheet.ciiva.com/7993/1776449-7993325.pdf?src-supplier=Element14; ComponentLink3URL: https://datasheet.ciiva.com/7993/1776449-7993325.pdf?src-supplier=Element14; ComponentLink4Description: https://datasheet.ciiva.com/7992/1776449-7992616.pdf?src-supplier=Element14; ComponentLink4URL: https://datasheet.ciiva.com/7992/1776449-7992616.pdf?src-supplier=Element14; ComponentLink5Description: https://datasheet.ciiva.com/2042/cd00000544-2042053.pdf?src-supplier=Digikey; ComponentLink5URL: https://datasheet.ciiva.com/2042/cd00000544-2042053.pdf?src-supplier=Digikey; ComponentLink6Description: https://datasheet.ciiva.com/2042/cd00000544-2042055.pdf?src-supplier=Digikey; ComponentLink6URL: https://datasheet.ciiva.com/2042/cd00000544-2042055.pdf?src-supplier=Digikey; ComponentLink7Description: https://datasheet.ciiva.com/2042/cd00000544-2042054.pdf?src-supplier=Digikey; ComponentLink7URL: https://datasheet.ciiva.com/2042/cd00000544-2042054.pdf?src-supplier=Digikey; Dropout Voltage1-Max: 1.3V; Height - Seated (Max): 2.63mm; JESD-30 Code: R-PSSO-G2; JESD-609 Code: e3; Length: 6.5mm; Library Name: Schlib1.SchLib; Load Regulation-Max: 0.03%; Manufacturer Part Number: LD1117DT33CTR; Max Supply Voltage: 15V; Moisture Sensitivity Level: 1; Number of Outputs: 1; Operating Temperature, TJ-Max: 125°C; Operating Temperature, TJ-Min: -40°C; Output Voltage1-Nom: 3.3V; Package Shape: Rectangular; Package Style: SMALL OUTLINEMeter; Packaging: Tape and Reel; Peak Reflow Temperature (Cel): 260°C; Pins: 2; Pricing: 1=0.52, 10=0.442, 25=0.4128, 100=0.3303, 250=0.30672, 500=0.25952, 1000=0.20055 (USD); Reach SVHC Compliant: No; RoHS: Yes; RoHS Compliant: Yes; Stock: 12130; Supplier: Digikey; Supplier Part Number: 497-1235-1-ND; Surface Mount: Yes; Technology: BIPOLAR; Terminal Finish: Tin; Terminal Form: Gull Wing; Terminal Pitch: 2.28mm; Terminal Position: SINGLE; Width: 6.1mm
U2 = ATMEGA64A-AU: RISC Microcontroller, 8-Bit, FLASH, AVR RISC CPU, 16MHz, CMOS, PQFP64; Manufacturer: Microchip; Max Supply Current: 20mA; Max Supply Voltage: 5.5V; Min Supply Voltage: 4.5V; Nominal Supply Voltage: 5V; Number of I/O Lines: 53; Package Body Material: Plastic; Power Supplies: 3/5V; Qualification Status: Not Qualified; Speed: 16RPM; Case/Package: TQFP; Clock Frequency: 16MHz; Component Kind: Standard; ComponentLink1Description: https://datasheet.ciiva.com:443/pdfs/VipMasterIC/IC/ATML/ATML-S-A0001248528/ATML-S-A0001248528-1.pdf?src-supplier=IHS+Markit; ComponentLink1URL: https://datasheet.ciiva.com:443/pdfs/VipMasterIC/IC/ATML/ATML-S-A0001248528/ATML-S-A0001248528-1.pdf?src-supplier=IHS+Markit; ComponentLink2Description: https://datasheet.ciiva.com/23822/1693903-23822389.pdf?src-supplier=Newark; ComponentLink2URL: https://datasheet.ciiva.com/23822/1693903-23822389.pdf?src-supplier=Newark; ComponentLink3Description: https://datasheet.ciiva.com/15213/getdatasheetpartid-296415-15213176.pdf?src-supplier=Verical; ComponentLink3URL: https://datasheet.ciiva.com/15213/getdatasheetpartid-296415-15213176.pdf?src-supplier=Verical; ComponentLink4Description: https://datasheet.ciiva.com/26206/atmel-8160-8-bit-avr-microcontroller-atmega64a-datasheet-26206844.pdf?; ComponentLink4URL: https://datasheet.ciiva.com/26206/atmel-8160-8-bit-avr-microcontroller-atmega64a-datasheet-26206844.pdf?; Height - Seated (Max): 1.2mm; JESD-30 Code: S-PQFP-G64; JESD-609 Code: e3; Length: 14mm; Library Name: Schlib1.SchLib; Manufacturer Part Number: ATMEGA64A-AU; Max Operating Temperature: 85°C; Min Operating Temperature: -40°C; Moisture Sensitivity Level: 3; Package Shape: Square; Package Style: FLATPACK, THIN PROFILEMeter; Pins: 64; Pricing: 1=5.76, 25=5.28, 100=4.78 (USD); RoHS: Yes; RoHS Compliant: Yes; Stock: 9358; Supplier: Digikey; Supplier Part Number: ATMEGA64A-AU-ND; Surface Mount: Yes; Technology: CMOS; Temperature Grade: INDUSTRIAL; Terminal Finish: Tin; Terminal Form: Gull Wing; Terminal Pitch: 0.8mm; Terminal Position: QUAD; Width: 14mm
U3 = L7805CD2T-TR: Fixed Positive Standard Regulator, 5VBIPolar, PSSO2; Manufacturer: STMicroelectronics; Channels: 1; Dropout Voltage1-Nom: 2V; Input Voltage Absolute-Max: 35V; Line Regulation-Max: 0.1%; Max Supply Voltage: 25V; Min Input Voltage: 7V; Output Current1-Max: 1.5A; Output Voltage1-Max: 5.25V; Output Voltage1-Min: 4.75V; Output Voltage1-Nom: 5V; Package Body Material: Plastic; Qualification Status: Not Qualified; Regulator Type: FIXED POSITIVE SINGLE OUTPUT STANDARD REGULATOR; Time @ Peak Reflow Temperature-Max (s): 30s; Voltage Tolerance-Max: 5%; Adjustability: FIXED; Case/Package: TO-263; Category: Voltage Regulators - Linear; Component Kind: Standard; ComponentLink1Description: https://datasheet.ciiva.com:443/pdfs/VipMasterIC/IC/SGST/SGST-S-A0006334694/SGST-S-A0006334694-1.pdf?src-supplier=IHS+Markit; ComponentLink1URL: https://datasheet.ciiva.com:443/pdfs/VipMasterIC/IC/SGST/SGST-S-A0006334694/SGST-S-A0006334694-1.pdf?src-supplier=IHS+Markit; ComponentLink2Description: https://datasheet.ciiva.com/36526/1991686-36526238.pdf?src-supplier=Jameco; ComponentLink2URL: https://datasheet.ciiva.com/36526/1991686-36526238.pdf?src-supplier=Jameco; ComponentLink3Description: https://datasheet.ciiva.com/22710/1805459-22710937.pdf?src-supplier=Element14; ComponentLink3URL: https://datasheet.ciiva.com/22710/1805459-22710937.pdf?src-supplier=Element14; ComponentLink4Description: https://datasheet.ciiva.com/7647/1805459-7647813.pdf?src-supplier=Element14; ComponentLink4URL: https://datasheet.ciiva.com/7647/1805459-7647813.pdf?src-supplier=Element14; ComponentLink5Description: https://datasheet.ciiva.com/2053/cd00000444-2053731.pdf?src-supplier=Digikey; ComponentLink5URL: https://datasheet.ciiva.com/2053/cd00000444-2053731.pdf?src-supplier=Digikey; ComponentLink6Description: https://datasheet.ciiva.com/2053/cd00000444-2053730.pdf?src-supplier=Digikey; ComponentLink6URL: https://datasheet.ciiva.com/2053/cd00000444-2053730.pdf?src-supplier=Digikey; ComponentLink7Description: https://datasheet.ciiva.com/4881/cd00000444-4881992.pdf?src-supplier=Mouser; ComponentLink7URL: https://datasheet.ciiva.com/4881/cd00000444-4881992.pdf?src-supplier=Mouser; ComponentLink8Description: https://datasheet.ciiva.com/46618/1805459-46618855.pdf?src-supplier=Newark; ComponentLink8URL: https://datasheet.ciiva.com/46618/1805459-46618855.pdf?src-supplier=Newark; ComponentLink9Description: https://datasheet.ciiva.com/1511/1805459-1511910.pdf?src-supplier=Element14; ComponentLink9URL: https://datasheet.ciiva.com/1511/1805459-1511910.pdf?src-supplier=Element14; ComponentLink10Description: https://datasheet.ciiva.com/2053/cd00000444-2053732.pdf?src-supplier=Digikey; ComponentLink10URL: https://datasheet.ciiva.com/2053/cd00000444-2053732.pdf?src-supplier=Digikey; ComponentLink11Description: https://datasheet.ciiva.com/16385/cd00000444-16385288.pdf?src-supplier=Future+Electronics; ComponentLink11URL: https://datasheet.ciiva.com/16385/cd00000444-16385288.pdf?src-supplier=Future+Electronics; Height - Seated (Max): 4.83mm; JESD-30 Code: R-PSSO-G2; JESD-609 Code: e3; Length: 10.2mm; Library Name: Schlib1.SchLib; Load Regulation-Max: 0.1%; Manufacturer Part Number: L7805CD2T-TR; Moisture Sensitivity Level: 1; Number of Outputs: 1; Operating Temperature, TJ-Max: 125°C; Operating Temperature, TJ-Min: 0°C; Package Shape: Rectangular; Package Style: SMALL OUTLINE, HEAT SINK/SLUGMeter; Packaging: Tape and Reel; Peak Reflow Temperature (Cel): 245°C; Pins: 2; Pricing: 1=0.83, 10=0.73, 25=0.6864, 100=0.56, 250=0.5202, 500=0.44272 (USD); Reach SVHC Compliant: No; RoHS: Yes; RoHS Compliant: Yes; Stock: 10418; Supplier: Digikey; Supplier Part Number: 497-1171-1-ND; Surface Mount: Yes; Technology: BIPOLAR; Terminal Finish: Tin; Terminal Form: Gull Wing; Terminal Pitch: 2.54mm; Terminal Position: SINGLE; Width: 9.15mm
Y1 = ECS-80-10-33-CHN-TR3: Crystal 8.0000MHZ 10PF SMD; Manufacturer: ECS International; Component Kind: Standard; ComponentLink1Description: https://datasheet.octopart.com/ECS-80-10-33-CHN-TR3-ECS-International-datasheet-140089731.pdf?src-supplier=Master+Electronics; ComponentLink1URL: https://datasheet.octopart.com/ECS-80-10-33-CHN-TR3-ECS-International-datasheet-140089731.pdf?src-supplier=Master+Electronics; Library Name: Schlib1.SchLib; Manufacturer Part Number: ECS-80-10-33-CHN-TR3; Pricing: 1=0.7, 10=0.581, 50=0.5226, 100=0.4646, 500=0.44136, 1000=0.37168 (USD); RoHS: No; Stock: 5712; Supplier: Digikey; Supplier Part Number: 50-ECS-80-10-33-CHN-TR3CT-ND
